# Altium schematic sheet LEDS.SchDoc
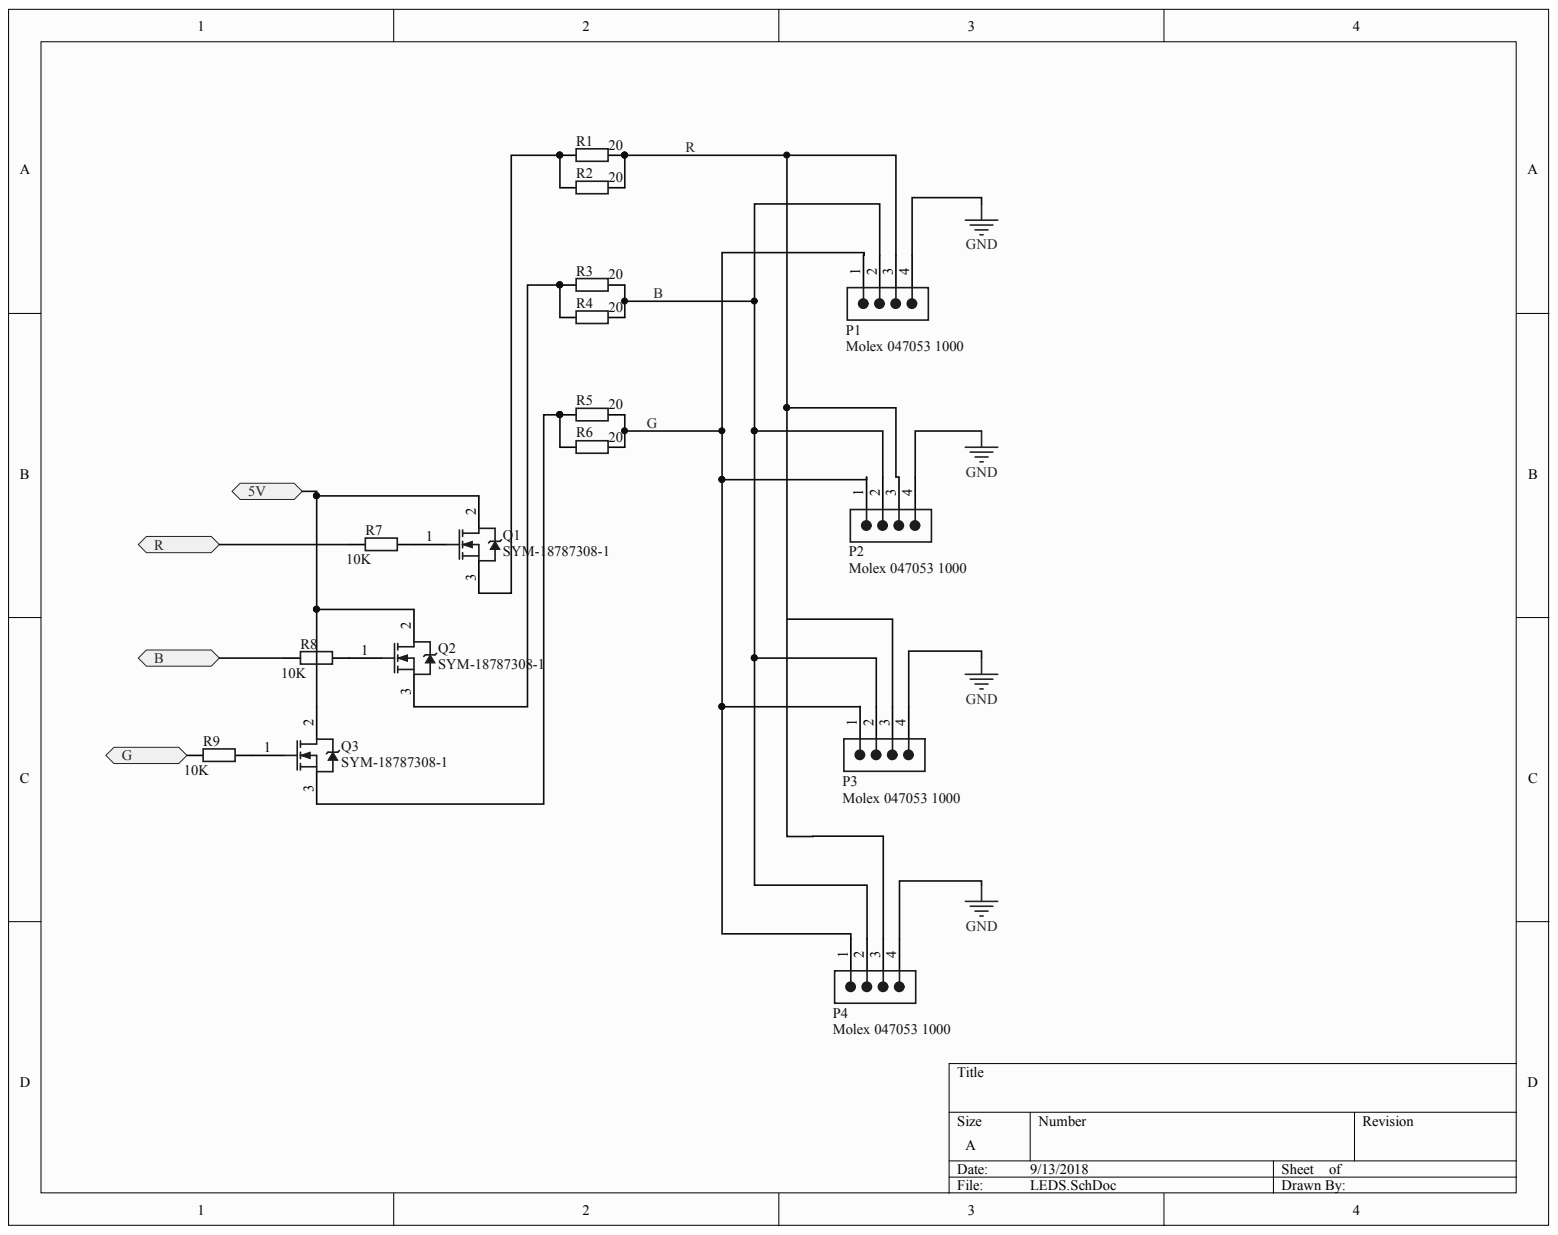
[diagram p0: LEDS.SchDoc]

## Parts
P1  Molex 047053 1000  [PCB-kyzvxp2nvgyimcljxmwn-1]
P2  Molex 047053 1000  [PCB-kyzvxp2nvgyimcljxmwn-1]
P3  Molex 047053 1000  [PCB-kyzvxp2nvgyimcljxmwn-1]
P4  Molex 047053 1000  [PCB-kyzvxp2nvgyimcljxmwn-1]
Q1  SYM-18787308-1  [PCB-18787308-1]
Q2  SYM-18787308-1  [PCB-18787308-1]
Q3  SYM-18787308-1  [PCB-18787308-1]
R1  Res2  [PCB-mctr3h3tvednl0z90ud0-1]  (value not drawn)
R2  Res2  [PCB-mctr3h3tvednl0z90ud0-1]  (value not drawn)
R3  Res2  [PCB-mctr3h3tvednl0z90ud0-1]  (value not drawn)
R4  Res2  [PCB-mctr3h3tvednl0z90ud0-1]  (value not drawn)
R5  Res2  [PCB-mctr3h3tvednl0z90ud0-1]  (value not drawn)
R6  Res2  [PCB-mctr3h3tvednl0z90ud0-1]  (value not drawn)
R7  Ohms: 10K  [PCB-mctr3h3tvednl0z90ud0-1]  (hidden comment: Res2)
R8  Ohms: 10K  [PCB-mctr3h3tvednl0z90ud0-1]  (hidden comment: Res2)
R9  Ohms: 10K  [PCB-mctr3h3tvednl0z90ud0-1]  (hidden comment: Res2)

## Ports
5V, B, G, R

## Nets
GND: P1.4 P2.4 P3.4 P4.4
5V: Q1.2 Q2.2 Q3.2 ; port 5V
B: P1.2 P2.2 P3.2 P4.2 R3.2 R4.2 R8.1 ; port B
G: P1.1 P2.1 P3.1 P4.1 R5.2 R6.2 R9.1 ; port G
R: P1.3 P2.3 P4.3 R1.2 R2.2 R7.1 ; port R
NetQ1_1: Q1.1 R7.2
NetQ1_3: Q1.3 R1.1 R2.1
NetQ2_1: Q2.1 R8.2
NetQ2_3: Q2.3 R3.1 R4.1
NetQ3_1: Q3.1 R9.2
NetQ3_3: Q3.3 R5.1 R6.1

Not connected: P3 3

## Library data of the parts
P1-P4 = CMP-d93b10f8176306fc-1: 2.54mm Pitch Vertical Header, with Friction Lock, 4 Circuits, PC Tail Length: 3.50mm; Component Kind: Standard; Library Name: CommunityVault; Lifecycle Status: Not Listed by Manufacturer; OctopartID: d93b10f8176306fc; Octopart URL: https://octopart.com/0470531000-molex-13232958; RoHS: Compliant
Q1-Q3 = CMP-18787308-5: MS; Part Family: IRFZ44N; Breakdown Voltage (Drain to Source): 55.0 V; Continuous Drain Current (Ids): 49.0 A; Drain to Source Resistance (on) (Rds): 17.5 mΩ; Gate Charge: 63.0 nC; Input Capacitance: 1.47 nF; Lead-Free Status: Lead Free; Power Dissipation: 83.0 W; Rise Time: 60.0 ns; Component Kind: Standard; Current Rating: 49.0 A; Library Name: CommunityVault; Lifecycle Status: Active; Mounting Style: Through Hole; Number of Pins: 3; OctopartID: 444b8d311b939b8c; Octopart URL: http://octopart.com/irfz44npbf-international+rectifier-7371454; REACH SVHC Compliance: No SVHC; Voltage Rating (DC): 55.0 V
R1-R6 = CMP-1413dd18cda2cbd4-1: Resistor; Carbon Composition; Res 3.9 Kilohms; Pwr-Rtg 0.25 W; Tol 5%; Axial; Manufacturer Part Number: OD392JE; Lead-Free Status: Lead Free; Ohms: 20; Power Rating: 250 mW; Component Kind: Standard; Library Name: CommunityVault; Mounting Style: Through Hole, Axial; OctopartID: 1413dd18cda2cbd4; Resistance Tolerance: ±5%; Size-Diameter: 2.50 mm
R7-R9 = CMP-1413dd18cda2cbd4-1: Resistor; Carbon Composition; Res 3.9 Kilohms; Pwr-Rtg 0.25 W; Tol 5%; Axial; Manufacturer Part Number: OD392JE; Lead-Free Status: Lead Free; Power Rating: 250 mW; Component Kind: Standard; Library Name: CommunityVault; Mounting Style: Through Hole, Axial; OctopartID: 1413dd18cda2cbd4; Resistance Tolerance: ±5%; Size-Diameter: 2.50 mm
